# Altium schematic sheet LCD&SRAM.SchDoc
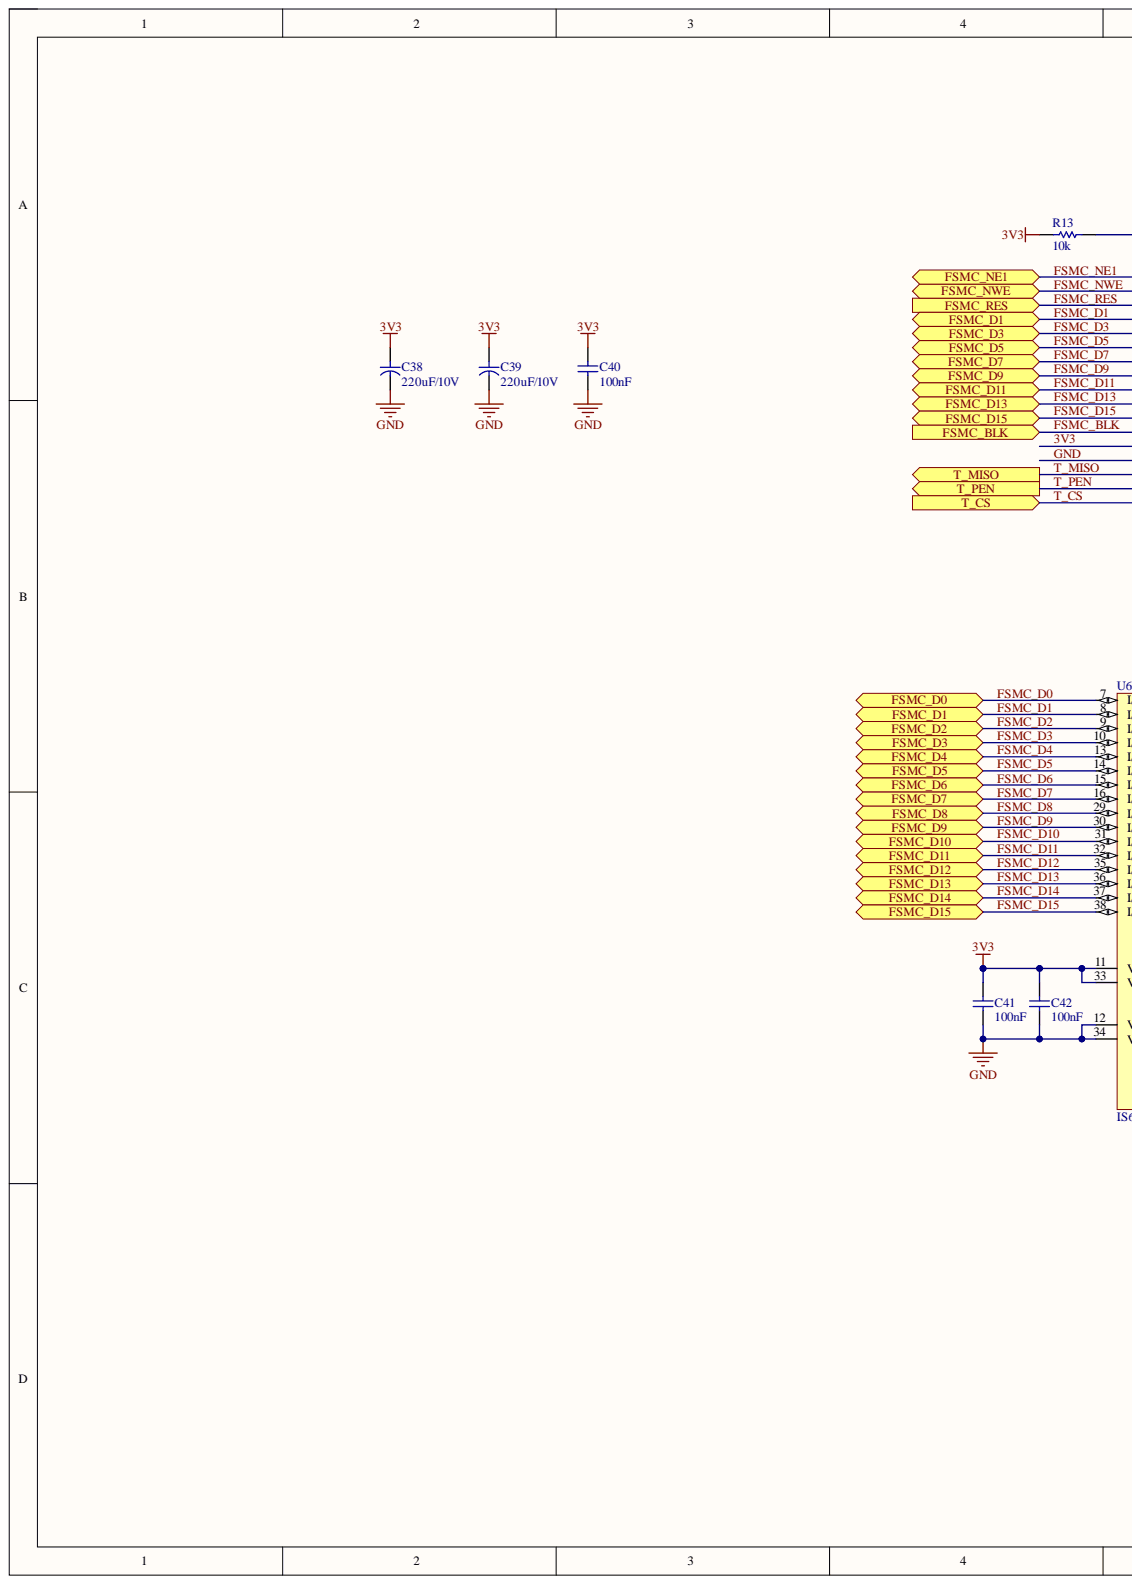
[diagram p0: LCD&SRAM.SchDoc, left]
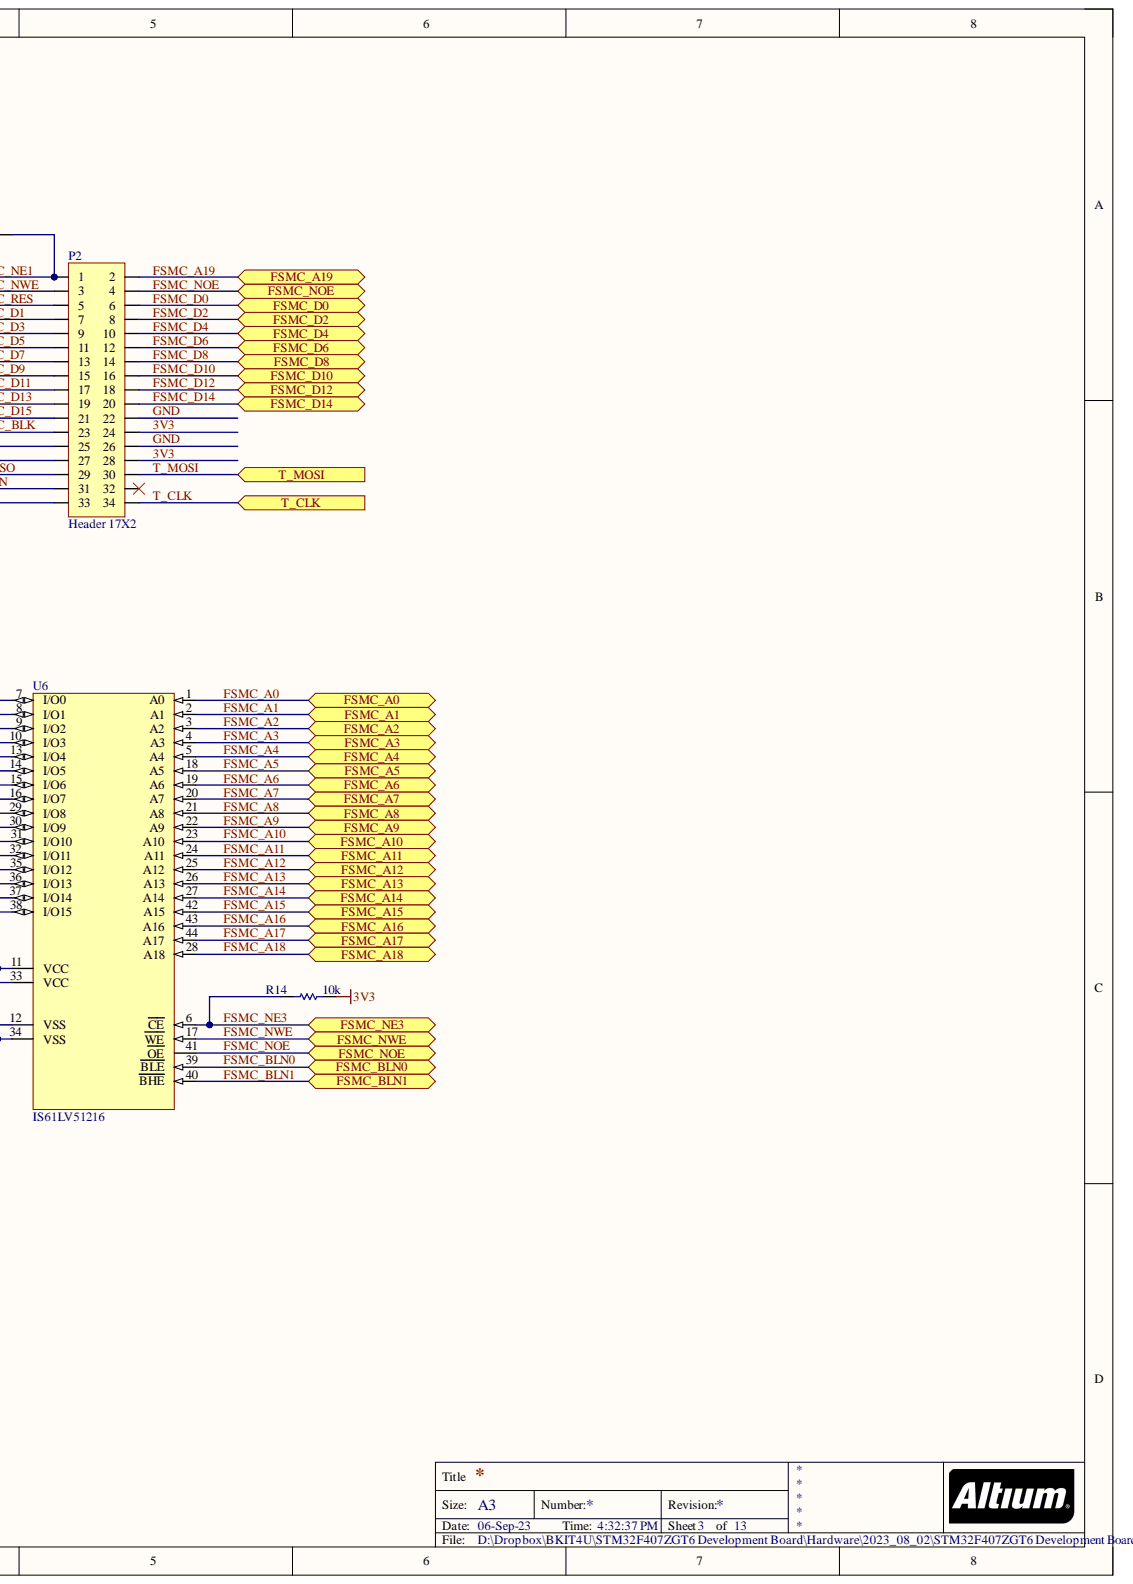
[diagram p1: LCD&SRAM.SchDoc, right]

## Parts
C38  220uF/10V  [C SMD ALU (D)]
C39  220uF/10V  [C SMD ALU (D)]
C40  100nF  [C 0603 (1608)]
C41  100nF  [C 0603 (1608)]
C42  100nF  [C 0603 (1608)]
P2  Header 17X2  [DS1023-2x17SF11]
R13  10k  [R 0603 (1608)]
R14  10k  [R 0603 (1608)]
U6  IS61LV51216  [TSOP-44]

## Ports
FSMC_A0, FSMC_A1, FSMC_A2, FSMC_A3, FSMC_A4, FSMC_A5, FSMC_A6, FSMC_A7, FSMC_A8, FSMC_A9, FSMC_A10, FSMC_A11, FSMC_A12, FSMC_A13, FSMC_A14, FSMC_A15, FSMC_A16, FSMC_A17, FSMC_A18, FSMC_A19, FSMC_BLK, FSMC_BLN0, FSMC_BLN1, FSMC_D0, FSMC_D1, FSMC_D2, FSMC_D3, FSMC_D4, FSMC_D5, FSMC_D6, FSMC_D7, FSMC_D8, FSMC_D9, FSMC_D10, FSMC_D11, FSMC_D12, FSMC_D13, FSMC_D14, FSMC_D15, FSMC_NE1, FSMC_NE3, FSMC_NOE, FSMC_NWE, FSMC_RES, T_CLK, T_CS, T_MISO, T_MOSI, T_PEN

## Nets
3V3: C38.1 C39.1 C40.2 C41.2 C42.2 P2.24 P2.25 P2.28 R13.2 R14.2 U6.11(VCC) U6.33(VCC)
FSMC_A0: U6.1(A0) ; port FSMC_A0
FSMC_A1: U6.2(A1) ; port FSMC_A1
FSMC_A2: U6.3(A2) ; port FSMC_A2
FSMC_A3: U6.4(A3) ; port FSMC_A3
FSMC_A4: U6.5(A4) ; port FSMC_A4
FSMC_A5: U6.18(A5) ; port FSMC_A5
FSMC_A6: U6.19(A6) ; port FSMC_A6
FSMC_A7: U6.20(A7) ; port FSMC_A7
FSMC_A8: U6.21(A8) ; port FSMC_A8
FSMC_A9: U6.22(A9) ; port FSMC_A9
FSMC_A10: U6.23(A10) ; port FSMC_A10
FSMC_A11: U6.24(A11) ; port FSMC_A11
FSMC_A12: U6.25(A12) ; port FSMC_A12
FSMC_A13: U6.26(A13) ; port FSMC_A13
FSMC_A14: U6.27(A14) ; port FSMC_A14
FSMC_A15: U6.42(A15) ; port FSMC_A15
FSMC_A16: U6.43(A16) ; port FSMC_A16
FSMC_A17: U6.44(A17) ; port FSMC_A17
FSMC_A18: U6.28(A18) ; port FSMC_A18
FSMC_A19: P2.2 ; port FSMC_A19
FSMC_BLK: P2.23 ; port FSMC_BLK
FSMC_BLN0: U6.39(BLE) ; port FSMC_BLN0
FSMC_BLN1: U6.40(BHE) ; port FSMC_BLN1
FSMC_D0: P2.6 U6.7(I/O0) ; port FSMC_D0
FSMC_D1: P2.7 U6.8(I/O1) ; port FSMC_D1
FSMC_D2: P2.8 U6.9(I/O2) ; port FSMC_D2
FSMC_D3: P2.9 U6.10(I/O3) ; port FSMC_D3
FSMC_D4: P2.10 U6.13(I/O4) ; port FSMC_D4
FSMC_D5: P2.11 U6.14(I/O5) ; port FSMC_D5
FSMC_D6: P2.12 U6.15(I/O6) ; port FSMC_D6
FSMC_D7: P2.13 U6.16(I/O7) ; port FSMC_D7
FSMC_D8: P2.14 U6.29(I/O8) ; port FSMC_D8
FSMC_D9: P2.15 U6.30(I/O9) ; port FSMC_D9
FSMC_D10: P2.16 U6.31(I/O10) ; port FSMC_D10
FSMC_D11: P2.17 U6.32(I/O11) ; port FSMC_D11
FSMC_D12: P2.18 U6.35(I/O12) ; port FSMC_D12
FSMC_D13: P2.19 U6.36(I/O13) ; port FSMC_D13
FSMC_D14: P2.20 U6.37(I/O14) ; port FSMC_D14
FSMC_D15: P2.21 U6.38(I/O15) ; port FSMC_D15
FSMC_NE1: P2.1 R13.1 ; port FSMC_NE1
FSMC_NE3: R14.1 U6.6(CE) ; port FSMC_NE3
FSMC_NOE: P2.4 U6.41(OE) ; port FSMC_NOE
FSMC_NWE: P2.3 U6.17(WE) ; port FSMC_NWE
FSMC_RES: P2.5 ; port FSMC_RES
GND: C38.2 C39.2 C40.1 C41.1 C42.1 P2.22 P2.26 P2.27 U6.12(VSS) U6.34(VSS)
T_CLK: P2.34 ; port T_CLK
T_CS: P2.33 ; port T_CS
T_MISO: P2.29 ; port T_MISO
T_MOSI: P2.30 ; port T_MOSI
T_PEN: P2.31 ; port T_PEN

Not connected: P2 32

## Library data of the parts
C38 C39 = Capacitor: Capacitor pol; Component Kind: Standard; Library Name: BKIT4U_LIB.SchLib
C40-C42 = Capacitor NP: Capacitor non-pol; Component Kind: Standard; Library Name: BKIT4U_LIB.SchLib
P2 = Header 17X2: Header, 34-Pin, Dual row; Component Kind: Standard; LatestRevisionDate: 17-Jul-2002; LatestRevisionNote: Re-released for DXP Platform.; Library Name: BKIT4U_LIB.SchLib; Publisher: Altium Limited
R13 R14 = Resistor: Component Kind: Standard; Description: Resistor; LatestRevisionDate: 17-Jul-2002; LatestRevisionNote: Re-released for DXP Platform.; Library Name: BKIT4U_LIB.SchLib; PackageReference: AXIAL-0.3; Published: 8-Jun-2000; Publisher: Altium Limited
U6 = IS61LV51216: 4-MBit SRAM; Component Kind: Standard; Library Name: BKIT4U_LIB.SchLib
